# Altium schematic sheet Connectors.SchDoc(Connectors)
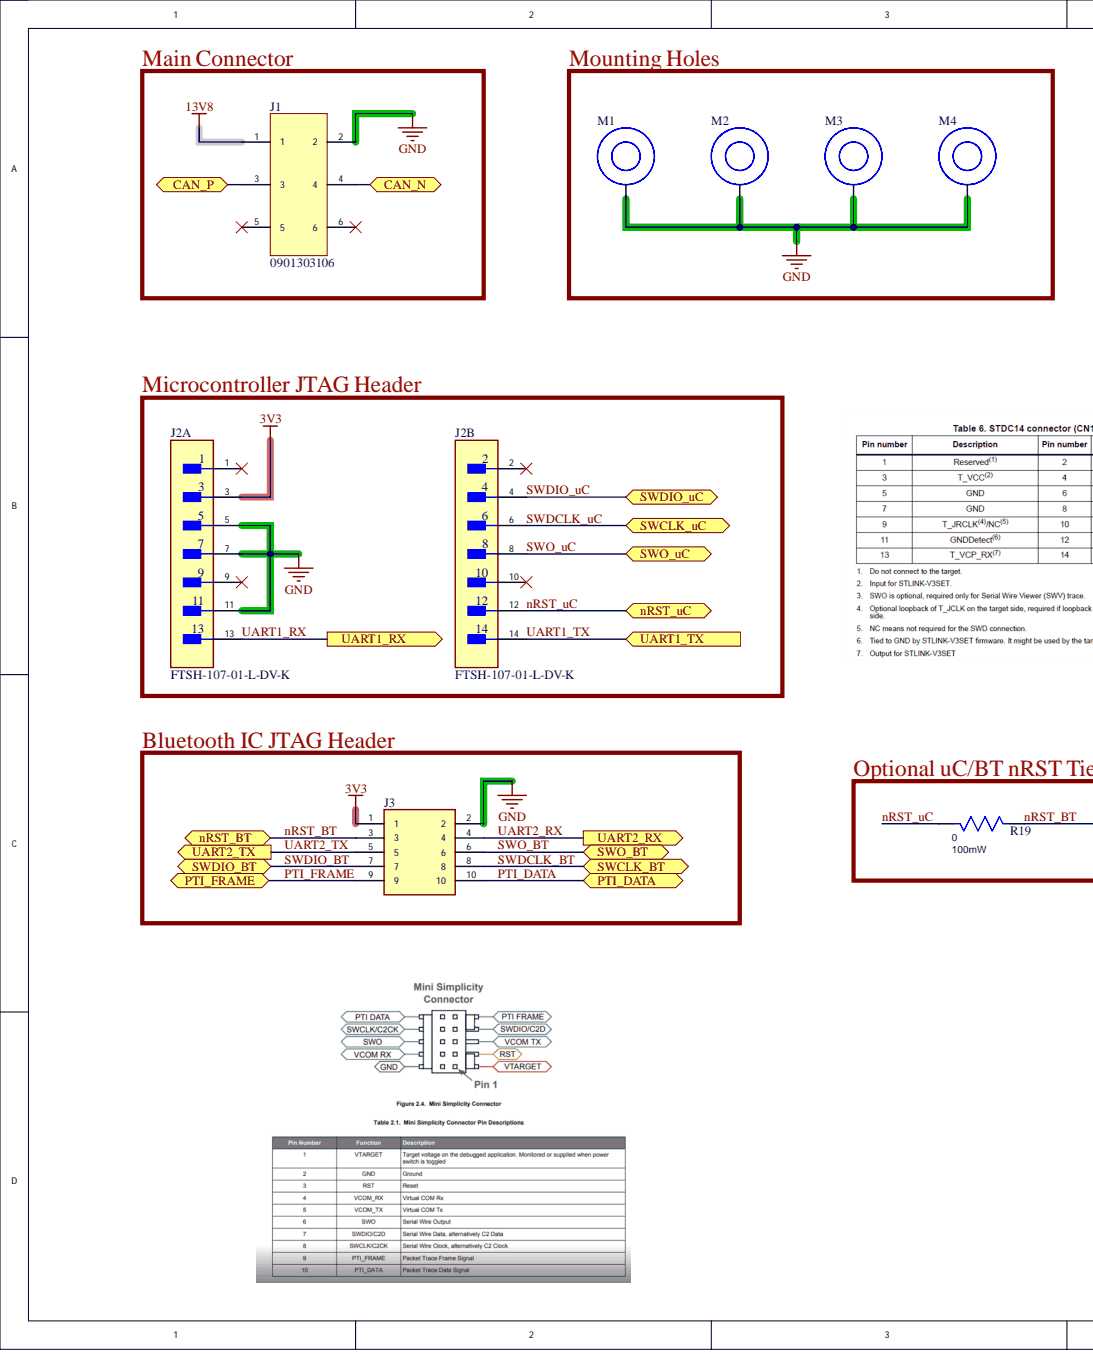
[diagram p0: Connectors.SchDoc, left]
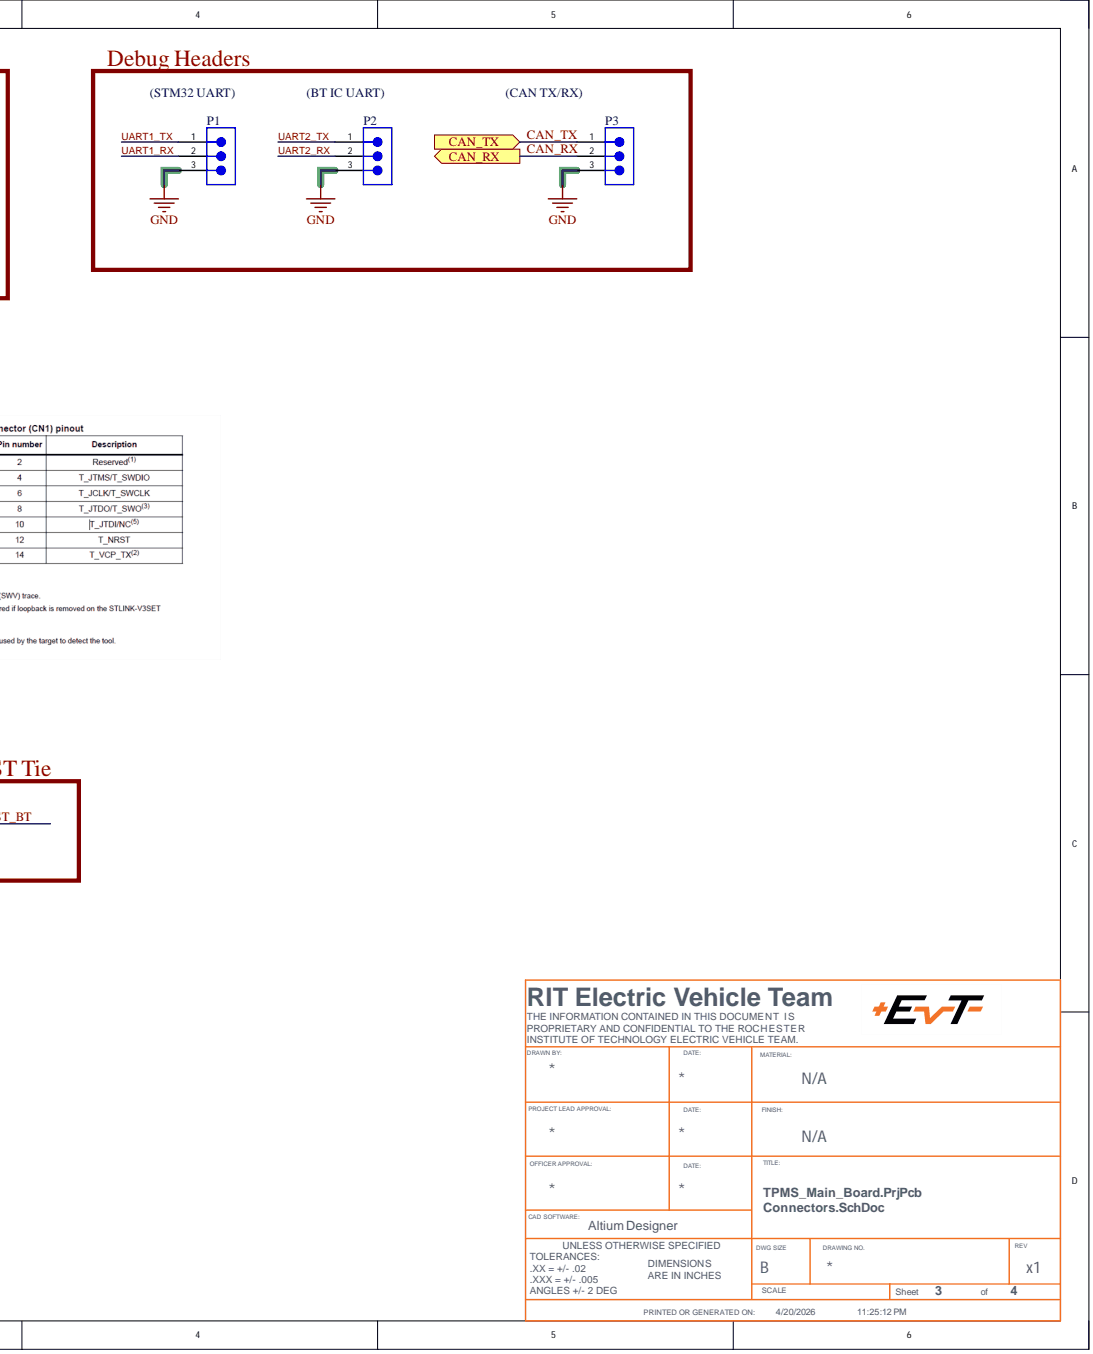
[diagram p1: Connectors.SchDoc, right]

## Parts
J1  0901303106
J2  FTSH-107-01-L-DV-K  (parts A,B)
J3  FTSH-105-01-L-DV-K  (value not drawn)
M1  M3 Mounting Hole  (value not drawn)
M2  M3 Mounting Hole  (value not drawn)
M3  M3 Mounting Hole  (value not drawn)
M4  M3 Mounting Hole  (value not drawn)
P1  61300311121  (value not drawn)
P2  61300311121  (value not drawn)
P3  61300311121  (value not drawn)
R19  Power Rating: 100mW  (hidden comment: RES 0R 100m 1% 0603)

## Ports
CAN_N, CAN_P, CAN_RX, CAN_TX, nRST_BT, nRST_uC, PTI_DATA, PTI_FRAME, SWCLK_BT, SWCLK_uC, SWDIO_BT, SWDIO_uC, SWO_BT, SWO_uC, UART1_RX, UART1_TX, UART2_RX, UART2_TX

## Nets
3V3: J2.3 J3.1
13V8: J1.1
GND: J1.2 J2.5 J2.7 J2.11 J3.2 M1.1 M2.1 M3.1 M4.1 P1.3 P2.3 P3.3
CAN_N: J1.4 ; port CAN_N
CAN_P: J1.3 ; port CAN_P
CAN_RX: P3.2 ; port CAN_RX
CAN_TX: P3.1 ; port CAN_TX
nRST_BT: J3.3 R19.1 ; port nRST_BT
nRST_uC: J2.12 R19.2 ; port nRST_uC
PTI_DATA: J3.10 ; port PTI_DATA
PTI_FRAME: J3.9 ; port PTI_FRAME
SWDCLK_BT: J3.8 ; port SWCLK_BT
SWDCLK_uC: J2.6 ; port SWCLK_uC
SWDIO_BT: J3.7 ; port SWDIO_BT
SWDIO_uC: J2.4 ; port SWDIO_uC
SWO_BT: J3.6 ; port SWO_BT
SWO_uC: J2.8 ; port SWO_uC
UART1_RX: J2.13 P1.2 ; port UART1_RX
UART1_TX: J2.14 P1.1 ; port UART1_TX
UART2_RX: J3.4 P2.2 ; port UART2_RX
UART2_TX: J3.5 P2.1 ; port UART2_TX

Not connected: J1 5 6; J2 1 2 9 10

## Library data of the parts
J1 = CMP-00652-7: Connector Header Through Hole, Right Angle 6 position 0.100" (2.54mm); Keyed (Y/N): N; Num Contacts: 6; Component Kind: Standard; Datasheet: https://www.molex.com/content/dam/molex/molex-dot-com/products/automated/en-us/salesdrawingpdf/901/90130/901303106_sd.pdf?inline; JLC Basic Part (Y/N): Y; JLCPCB Part #: C17549547; MPN: 0901303106; Polarized? (Y/N): N; Library Name: RIT-EVT-Vault
J2 = CMP-00419-1: Board Connector, 14 Contact(s), 2 Row(s), Male, Straight, 0.05 inch Pitch, Surface Mount Terminal, Locking, Receptacle; Keyed (Y/N): Y; Num Contacts: 14; Component Kind: Standard; JLC Basic Part (Y/N): N; JLCPCB Part #: N/A; MPN: FTSH-107-01-L-DV-K; PartId: 1; Polarized? (Y/N): Y; Library Name: RIT-EVT-Vault
J3 = CMP-00682-2: CONN HEADER SMD 10POS 1.27MM; Keyed (Y/N): Y; Num Contacts: 10; Polarized? (Y/N): N; Component Kind: Standard; JLC Basic Part (Y/N): Y; JLCPCB Part #: C5155080; MPN: FTSH-105-01-L-DV-K; Library Name: RIT-EVT-Vault
M1-M4 = CMP-00427-1: M3 screw mounting hole; Hole Diameter: 3.25mm; Pad Diameter: 6.5mm; PTH or NPTH: PTH; Component Kind: Standard; JLC BASIC Part (Y/N): N/A; JLCPCB Part #: N/A; MPN: N/A; Package: N/A; Library Name: RIT-EVT-Vault
P1-P3 = CMP-00675-1: WR-PHD Straight Male, PCB Header, Through Hole, 1 Rows, 3 Contacts, 2.54mm Pitch; Keyed (Y/N): N; Num Contacts: 3; Component Kind: Standard; JLC Basic Part (Y/N): N; JLCPCB Part #: 0; MPN: 61300311121; Polarized? (Y/N): N; Library Name: RIT-EVT-Vault
R19 = CMP-00521-2: -55℃~+155℃ 0Ω 100mW 75V Thick Film Resistor ±1% 0603 Chip Resistor - Surface Mount ROHS; MPN: RC0603JR-070RL; Polarized (Y/N): N; Resistance: 0; Component Kind: Standard; JLC Basic Part (Y/N): Y; JLCPCB Part #: C21189; Package: 0603; Tolerance: 1%; Voltage Rating: 75V; Library Name: RIT-EVT-Vault
